# Altium schematic sheet B215.SchDoc(B215)
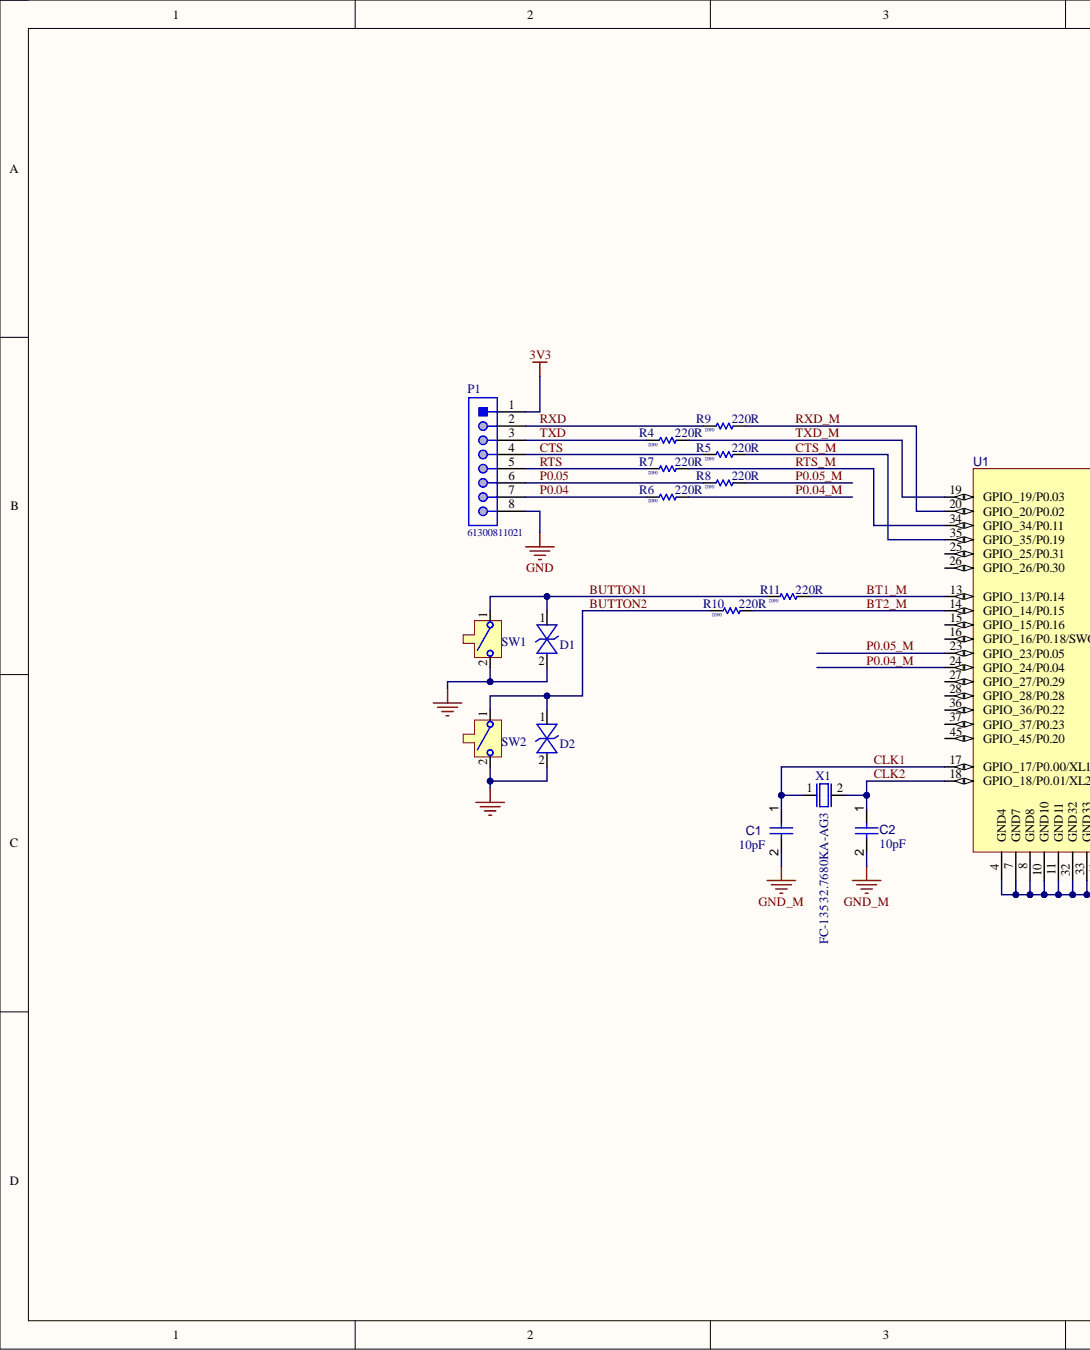
[diagram p0: B215.SchDoc, left]
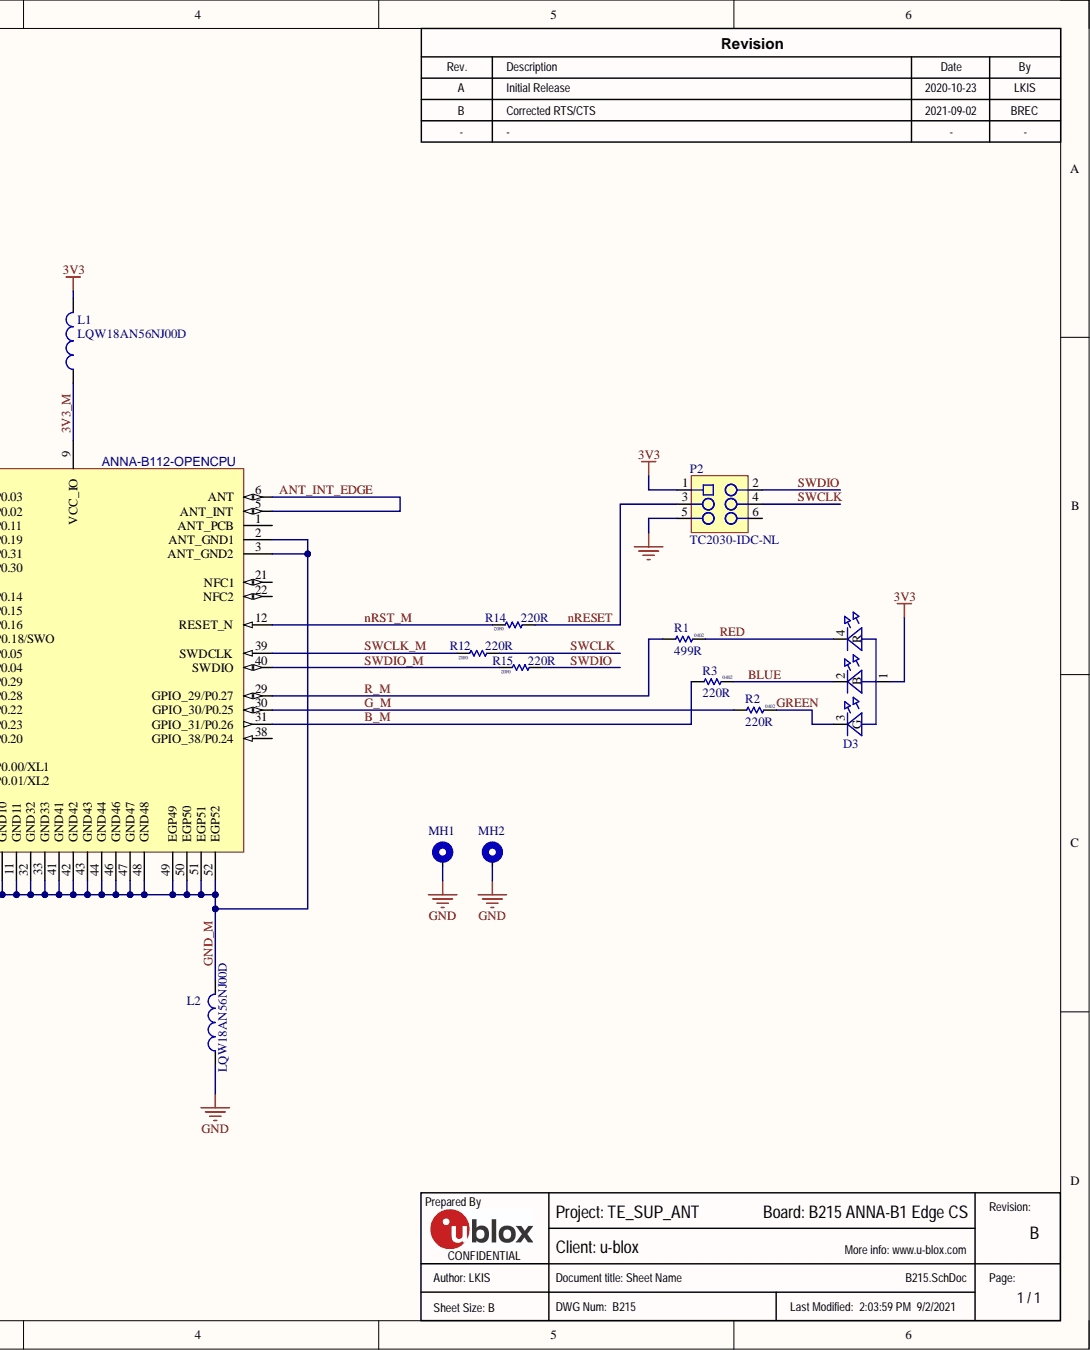
[diagram p1: B215.SchDoc, right]

## Parts
C1  value 10pF  [CAPC1005X55X25ML05T05]  (hidden comment: Capacitor 10 pF +/- 5% 50 V 0402)
C2  value 10pF  [CAPC1005X55X25ML05T05]  (hidden comment: Capacitor 10 pF +/- 5% 50 V 0402)
D1  110-00019  [SOD-923]  (value not drawn)
D2  110-00019  [SOD-923]  (value not drawn)
D3  115-00011  [4-PLCC]  (value not drawn)
L1  LQW18AN56NJ00D  [INDC1608X100X30LL20T10]
L2  LQW18AN56NJ00D  [INDC1608X100X30LL20T10]
MH1  MH_M1.5DNP  [MH_M1.5]  (value not drawn)
MH2  MH_M1.5DNP  [MH_M1.5]  (value not drawn)
P1  61300811021  [61300811021]
P2  TC2030-IDC-NLDNP  [TC2030-IDC-NL]  (value not drawn)
R1  value 499R  Size: 0402  [RES 0402 HD]  (hidden comment: 180-00031499R)
R2  value 220R  Size: 0402  [RES 0402 HD]  (hidden comment: 180-00098220R)
R3  value 220R  Size: 0402  [RES 0402 HD]  (hidden comment: 180-00098220R)
R4  value 220R  Size: 0402  [RES 0402 HD]  (hidden comment: 180-00098220R)
R5  value 220R  Size: 0402  [RES 0402 HD]  (hidden comment: 180-00098220R)
R6  value 220R  Size: 0402  [RES 0402 HD]  (hidden comment: 180-00098220R)
R7  value 220R  Size: 0402  [RES 0402 HD]  (hidden comment: 180-00098220R)
R8  value 220R  Size: 0402  [RES 0402 HD]  (hidden comment: 180-00098220R)
R9  value 220R  Size: 0402  [RES 0402 HD]  (hidden comment: 180-00098220R)
R10  value 220R  Size: 0402  [RES 0402 HD]  (hidden comment: 180-00098220R)
R11  value 220R  Size: 0402  [RES 0402 HD]  (hidden comment: 180-00098220R)
R12  value 220R  Size: 0402  [RES 0402 HD]  (hidden comment: 180-00098220R)
R14  value 220R  Size: 0402  [RES 0402 HD]  (hidden comment: 180-00098220R)
R15  value 220R  Size: 0402  [RES 0402 HD]  (hidden comment: 180-00098220R)
SW1  135-00007  [B3U-1000P]  (value not drawn)
SW2  135-00007  [B3U-1000P]  (value not drawn)
U1  ANNA-B112-OPENCPU  [ANNA_LGA52S_650X650]
X1  100-00005  [XTAL_3215]  (value not drawn)

## Nets
3V3: D3.1 L1.2 P1.1 P2.1
GND: D1.2 D2.2 L2.1 MH1.1 MH2.1 P1.8 P2.5 SW1.2 SW2.2
3V3_M: L1.1 U1.9(VCC_IO)
ANT_INT_EDGE: U1.5(ANT_INT) U1.6(ANT)
B_M: R3.1 U1.31(GPIO_31/P0.26)
BLUE: D3.2(B) R3.2
BT1_M: R11.1 U1.13(GPIO_13/P0.14)
BT2_M: R10.1 U1.14(GPIO_14/P0.15)
BUTTON1: D1.1 R11.2 SW1.1
BUTTON2: D2.1 R10.2 SW2.1
CLK1: C1.1 U1.17(GPIO_17/P0.00/XL1) X1.1
CLK2: C2.1 U1.18(GPIO_18/P0.01/XL2) X1.2
CTS: P1.4 R5.2
CTS_M: R5.1 U1.35(GPIO_35/P0.19)
G_M: R2.1 U1.30(GPIO_30/P0.25)
GND_M: C1.2 C2.2 L2.2 U1.2(ANT_GND1) U1.3(ANT_GND2) U1.4(GND4) U1.7(GND7) U1.8(GND8) U1.10(GND10) U1.11(GND11) U1.32(GND32) U1.33(GND33) U1.41(GND41) U1.42(GND42) U1.43(GND43) U1.44(GND44) U1.46(GND46) U1.47(GND47) U1.48(GND48) U1.49(EGP49) U1.50(EGP50) U1.51(EGP51) U1.52(EGP52)
GREEN: D3.3(G) R2.2
nRESET: P2.3 R14.1
nRST_M: R14.2 U1.12(RESET_N)
P0.04: P1.7 R6.2
P0.04_M: R6.1 U1.24(GPIO_24/P0.04)
P0.05: P1.6 R8.2
P0.05_M: R8.1 U1.23(GPIO_23/P0.05)
R_M: R1.1 U1.29(GPIO_29/P0.27)
RED: D3.4(R) R1.2
RTS: P1.5 R7.2
RTS_M: R7.1 U1.34(GPIO_34/P0.11)
RXD: P1.2 R9.2
RXD_M: R9.1 U1.20(GPIO_20/P0.02)
SWCLK: P2.4 R12.1
SWCLK_M: R12.2 U1.39(SWDCLK)
SWDIO: P2.2 R15.1
SWDIO_M: R15.2 U1.40(SWDIO)
TXD: P1.3 R4.2
TXD_M: R4.1 U1.19(GPIO_19/P0.03)

Not connected: P2 6; U1 1(ANT_PCB) 15(GPIO_15/P0.16) 16(GPIO_16/P0.18/SWO) 21(NFC1) 22(NFC2) 25(GPIO_25/P0.31) 26(GPIO_26/P0.30) 27(GPIO_27/P0.29) 28(GPIO_28/P0.28) 36(GPIO_36/P0.22) 37(GPIO_37/P0.23) 38(GPIO_38/P0.24) 45(GPIO_45/P0.20)

## Library data of the parts
C1 C2 = CMP-001-00013-4: Chip Capacitor, 10 pF, +/- 5%, 50 V, 0402 (1005 Metric); Capacitor Type: Ceramic; Voltage Rating: 50V; Case/Package: 0402; Component Kind: Standard; Max Operating Temperature: 125°C; Min Operating Temperature: -55°C; Mounting Technology: SM; Pins: 2; RoHS Compliant: True; Tolerance: 5%; Library Name: U-blox Sweden
D1 D2 = 110-00019: Diode, ESD9B, 3.3V, ST5G, SOD-923; Manufacturer: ON Semiconductor; Manufacturer P/N: ESD9B3.3ST5G; Part Name: Diode TVS 3.3V SOD-923; Body Size: 0.8mm x 0.6mm; Component Mounting Type: SMT; Diode Type: TVS; Item_Phase: Production; Use in new designs: Yes; Arena Link: https://app.bom.com/a/4M5DJQ9Q9SR7DV4H?v=spec.summary; Component Kind: Standard; Database Table Name: Diode; Height: 0.4mm; MFG Package: SOD-923; Package Type: SOD; Part Number: 110-00019; Part_Type: Diode; Pins: 2; Revision: 0A; Supplier 1: Arrow; Supplier Part Number 1: ESD9B3.3ST5G; Voltage: 3.3V; Library Name: Arena_Lib.DbLib
D3 = 115-00011: LED, RGB Diffused SMT, PLCC4 4 Pin; Manufacturer: Cree, Inc.; Manufacturer P/N: CLMVB-FKA-CFHEHLCBB7a363; Part Name: LED RGB SMT 2mm x 2mm; Body Size: 2.0mm x 2.0mm; Current: 20mA Red, 10mA Green, 10mA, Blue; Item_Phase: Production; Number of Circuits: 3; Use in new designs: Yes; Vf: 2V Red, 3V Green, 3V Blue; Voltage: 5V; Arena Link: https://app.bom.com/a/UCV39GZGZIHY581D?v=spec.summary; Color: RGB; Component Kind: Standard; Component Mounting Type: SMT; Database Table Name: LED; Height: 1mm; MFG Package: PLCC4; Mounting Type: Vertical; Package Type: Custom; Part Number: 115-00011; Part_Type: LED; Pins: 4; Revision: 0A; Supplier 1: Mouser; Supplier Part Number 1: 941-CLMVBFKACFHEHLCB; Library Name: Arena_Lib.DbLib
L1 L2 = CMP-2000-06600-1: Chip Inductor, 56 nH, +/-5%, 390 mA, -55 to 125 degC, 0603 (1608 Metric), RoHS, Tape and Reel; Manufacturer: Murata; Current Rating: 360mA; DC Resistance (DCR): 290mOhmMax; Frequency - Self Resonant: 2.4GHz; Frequency - Test: 100MHz; Inductance: 56nH; Material - Core: Non-Magnetic; Online Catalog: LQWSeries; Other Names: 490-6876-1; Product Training Modules: InductorProductsChipRFInductors; Q @ Freq: 38@200MHz; Shielding: Unshielded; Tolerance: ±5%; Type: Wirewound; Category: Inductors,Coils,Chokes; Component Kind: Standard; Datasheet URL: http://search.murata.co.jp/Ceramy/image/img/PDF/ENG/L0075S0080LQW18A_10.pdf; Datasheet Version: 1/2019; Family: FixedInductors; Height - Seated (Max): 0.039"(1.00mm); Library Name: Altium Content Vault; Manufacturer URL: http://www.murata.com/; Mounting Technology: SurfaceMount; Mounting Type: SurfaceMount; Operating Temperature: -55°C~125°C; Package / Case: 0603(1608Metric); Package Description: 2-Pin Surface Mount Device, Body 1.6 x 0.8 mm; Package Reference: 0603; Packaging: CutTape(CT); Series: LQW18A_00; Size / Dimension: 0.063"Lx0.031"W(1.60mmx0.80mm); Standard Package: 1
MH1 MH2 = MH_M1.5: 1.5M screw mounting hole, plated; Added By: Jeremy D; Database Table Name: Mechanical; Added Date: 2015-07-09; Component Kind: Standard; DNP: DNP; Height: 0mm; Part Number: MH_M1.5; Size: 3.4mm x 3.4mm; Type: Mounting Hole; Library Name: LEGACY_Rigado_AltiumLib.DbLib
P1 = CMP-1502-01075-1: THT Angled Pin Header WR-PHD, Pitch 2.54 mm, Single Row, 8 pins; Manufacturer: Wurth Elektronik; Contact Resistance: 20mOhm; Gender: Male; Pin Number: 8; Pitch: 2.54mm; Rated Current: 3A; Withstanding Voltage: 500VAC; Working Voltage: 250VAC; Component Kind: Standard; Datasheet URL: https://katalog.we-online.de/em/datasheet/6130xx11021.pdf; Library Name: 8-pin-ra-100mil.IntLib; Manufacturer Part Number: 61300811021; Manufacturer URL: http://www.we-online.de; Mounting Technology: THT; Operating Temperature: -40to105degC; Orientation: Angled; Package Reference: 61300811021; Packaging: Bag; Part Number: 61300811021; Row Number: Single; Publisher: WurthElektronik
P2 = TC2030-IDC-NL: CON PADS FOR CBL PLUG-OF-NAILS 6-PIN NO LEGS; Manufacturer: TAG Connect; Added By: Paul B; Database Table Name: Testpoints & Pads; Added Date: 2015-04-22; Component Kind: Standard; DNP: DNP; Height: 0; Manufacturer P/N: TC2030-IDC-NL; Part Number: TC2030-IDC-NL; Size: 6.731mm x 12.446mm; Library Name: LEGACY_Rigado_AltiumLib.DbLib
R1 = 180-00031: Resistor, 499ohm, 1%, 1/16W, 0402; Manufacturer: Rohm Semiconductor; Manufacturer P/N: MCR01MRTF4990; Part Name: RES SMD 499 OHM 1% 1/16W 0402; Component Mounting Type: SMT; Item_Phase: Production; Tolerance: +/- 1%; Use in new designs: Yes; Alternate Footprint Ref: RES 0402; Arena Link: https://app.bom.com/a/Q8RZ5CVCVEDU1SS5?v=spec.summary; Component Kind: Standard; Database Table Name: Resistor; Height: 0.4mm; Manufacturer 2: Samsung Electro-Mechanics America, Inc; Manufacturer P/N 2: RC1005F4990CS; Part Number: 180-00031; Part_Type: Resistor; Pins: 2; Power: 1/16W; Revision: 0D; Signal Integrity: Res; Simulation: RESISTOR; Supplier 1: Digi-Key; Supplier 2: Digi-Key; Supplier Part Number 1: RHM499CDCT-ND; Supplier Part Number 2: 1276-3992-1-ND; Library Name: Arena_Lib.DbLib
R2 R3 = 180-00098: Resistor, 220ohm, 5%, 1/16W, 0402.; Manufacturer: Panasonic Electronic Components; Manufacturer P/N: ERJ-2GEJ221X; Part Name: RES SMD 220 OHM 5% 1/16W 0402; Component Mounting Type: SMT; Item_Phase: Production; Tolerance: +/- 5%; Use in new designs: Yes; Alternate Footprint Ref: RES 0402; Arena Link: https://app.bom.com/a/8Q9HNUDUDWVBJC30?v=spec.summary; Component Kind: Standard; Database Table Name: Resistor; Height: 0.4mm; Manufacturer 2: Samsung Electro-Mechanics America, Inc; Manufacturer P/N 2: RC1005J221CS; Part Number: 180-00098; Part_Type: Resistor; Pins: 2; Power: 1/16W; Revision: 0C; Signal Integrity: Res; Simulation: RESISTOR; Supplier 1: Digi-Key; Supplier 2: Digi-Key; Supplier Part Number 1: P220JCT-ND; Supplier Part Number 2: 1276-4360-1-ND; Library Name: Arena_Lib.DbLib
R4-R12 R14 R15 = 180-00098: Resistor, 220ohm, 5%, 1/16W, 0402.; Manufacturer 1: Samsung Electro-Mechanics America, Inc; Manufacturer Part Number 1: RC1005J221CS; Part Name: RES SMD 220 OHM 5% 1/16W 0402; Item Phase: In Production; Tolerance: +/- 5%; UBX Code: None; Use in new designs: Yes; Component Kind: Standard; Database Table Name: Resistor; Height: 0.4mm; Manufacturer 2: Panasonic Electronic Components; Manufacturer Part Number 2: ERJ-2GEJ221X; Max Operating Temp: 155; Min Operating Temp: -55; Part Number: 180-00098; Pins: 2; Power: 1/16W; Revision: 0C; Signal Integrity: Res; Simulation: RESISTOR; Supplier 1: Digi-Key; Supplier 2: Digi-Key; Supplier Part Number 1: 1276-4360-1-ND; Supplier Part Number 2: P220JCT-ND; Voltage: None; Library Name: Arena_Parts_DB_Lib.DbLib
SW1 SW2 = 135-00007: Button, Momentary SPST-NO, 0.05A, 12V, 3mm x 2.5mm; Manufacturer: Omron Electronics Inc-EMC Div; Part Name: SWITCH TACTILE SPST-NO 0.05A 12V; Component Mounting Type: SMT; Current: 50mA; Item_Phase: Production; Switch/Button Circuit: SPST; Switch/Button Function: Off-Mom; Use in new designs: Yes; Voltage: 12VDC; Arena Link: https://app.bom.com/a/TBU28FYFYHGV5FQ0?v=spec.summary; Body Size: 3mm x 2.5mm; Component Kind: Standard; Database Table Name: Button; Height: 1.2mm; Manufacturer P/N: B3U-1000P; Part Number: 135-00007; Part_Type: Button; Pins: 2; Revision: 0A; Supplier 1: Digi-Key; Supplier Part Number 1: SW1020CT-ND; Library Name: Arena_Lib.DbLib
U1 = ANNA-B112-OPENCPU: u-blox ANNA-B112 Bluetooth 5 LE module; Part Description: Stand-alone Bluetooth low energy module; Component Kind: Standard; Library Name: ubloxLib.IntLib; Manufacturer: u-blox; Value: ANNA-B112-OPENCPU
X1 = 100-00005: XTAL, 2Pin, 32.768kHz, 7pF 20ppm; Manufacturer: Epson; Manufacturer P/N: FC-135 32.7680KA-AG3; Part Name: XTAL 32.768kHz 7pF 20ppm 3215; Body Size: 3.2mm x 1.5mm; Component Mounting Type: SMT; Database Table Name: Crystal/Oscillator; Item_Phase: Production; MFG Package: 2-SMD; Use in new designs: Yes; Arena Link: https://app.bom.com/a/L3MU07Q7Q98O5PC8?v=spec.summary; CL: 7pF; Component Kind: Standard; Frequency: 32.768kHz; Frequency Tolerance: 20ppm; Height: 0.9mm; Manufacturer 2: AVX Corporation; Manufacturer P/N 2: ST3215SB32768C0HPWBB; Part Number: 100-00005; Part_Type: Crystal/Oscillator; Pins: 2; Revision: 0C; Supplier 1: Digi-Key; Supplier 2: Digi-Key; Supplier Part Number 1: SER4086CT-ND; Supplier Part Number 2: 1253-1113-1-ND; Library Name: Arena_Lib.DbLib
